# Altium schematic sheet decoder.SchDoc
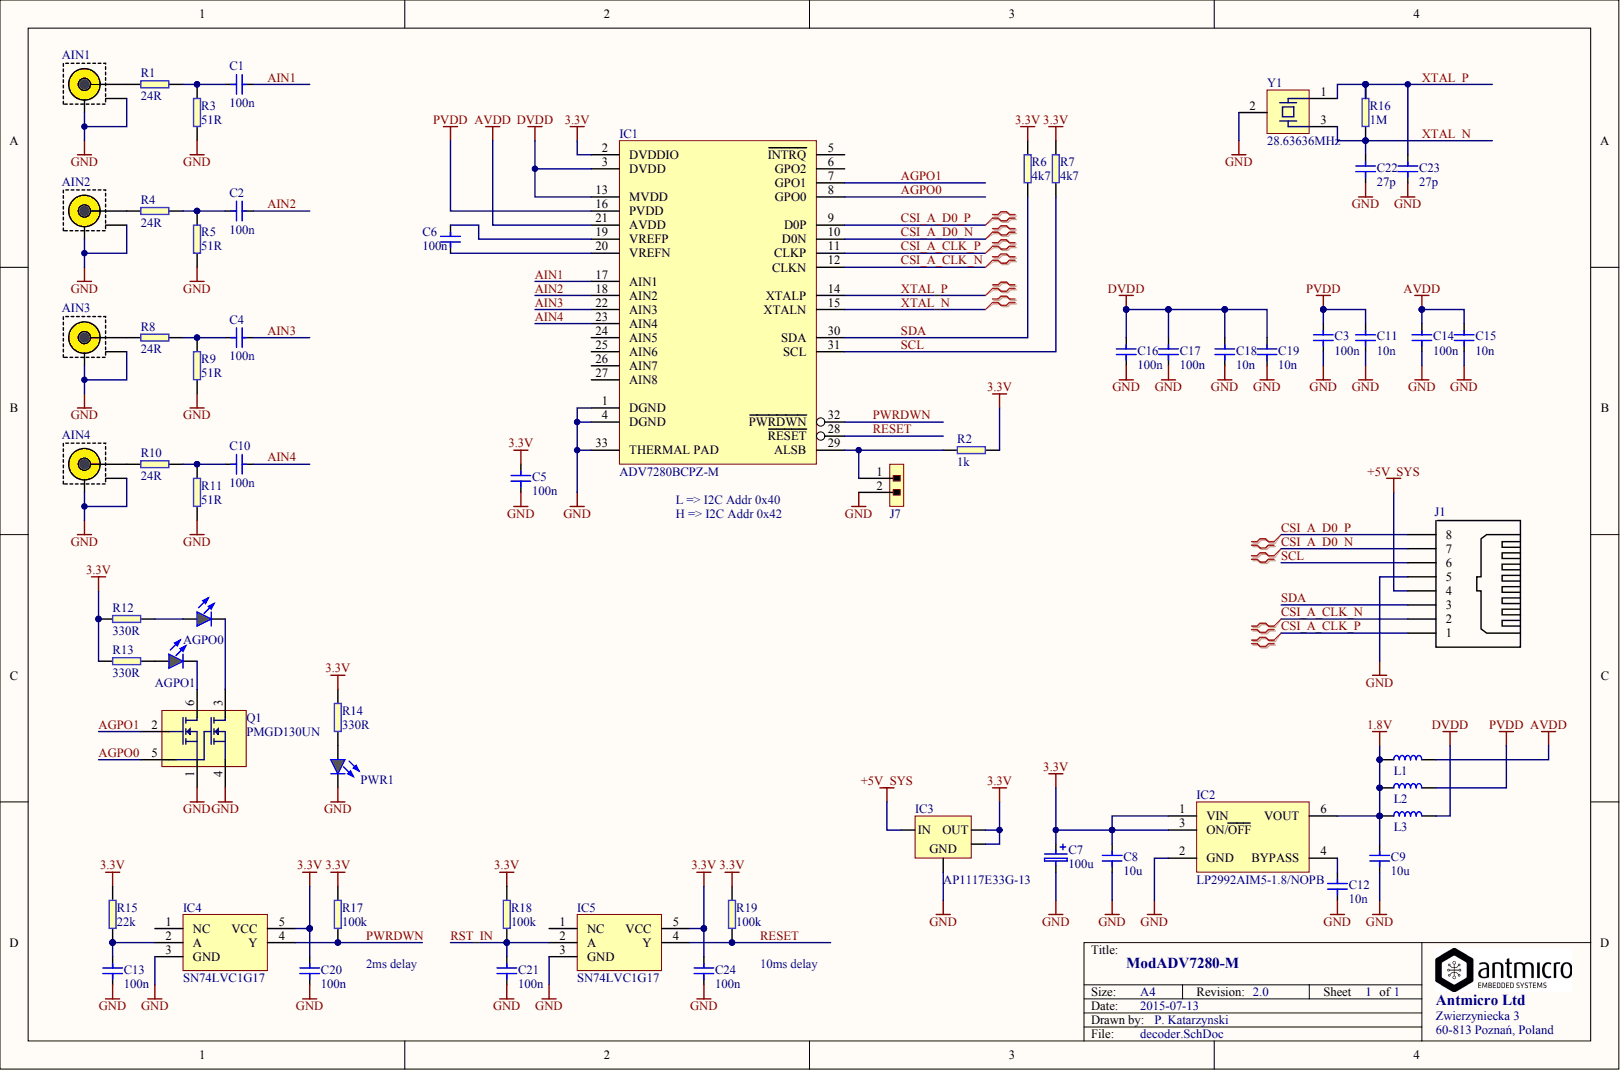
[diagram p0: decoder.SchDoc]

## Parts
AGPO0  *  [LED0603]  (value not drawn)
AGPO1  *  [LED0603]  (value not drawn)
AIN1  (no value)
AIN2  (no value)
AIN3  (no value)
AIN4  (no value)
C1  100n
C2  100n
C3  100n
C4  100n
C5  100n
C6  100n
C7  value 100u
C8  10u
C9  10u
C10  100n
C11  10n
C12  10n
C13  100n
C14  100n
C15  10n
C16  100n
C17  100n
C18  10n
C19  10n
C20  100n
C21  100n
C22  27p
C23  27p
C24  100n
IC1  ADV7280BCPZ-M
IC2  LP2992AIM5-1.8/NOPB
IC3  value AP1117E33G-13  (hidden comment: *)
IC4  SN74LVC1G17
IC5  SN74LVC1G17
J1  (no value)
J7  *  [HEADER_1X2_male 2.54mm]  (value not drawn)
L1  *  [0805]  (value not drawn)
L2  *  [0805]  (value not drawn)
L3  *  [0805]  (value not drawn)
PWR1  *  [LED0603]  (value not drawn)
Q1  PMGD130UN
R1  24R
R2  1k
R3  51R
R4  24R
R5  51R
R6  4k7
R7  4k7
R8  24R
R9  51R
R10  24R
R11  51R
R12  330R
R13  330R
R14  330R
R15  22k
R16  1M
R17  100k
R18  100k
R19  100k
Y1  28.63636MHz  [TXC_7B]

## Nets
1.8V: C9.2 IC2.6(VOUT) L1.1 L2.1 L3.1
3.3V: C5.2 C7.1 C8.2 C20.2 C24.2 IC1.2(DVDDIO) IC2.1(VIN) IC2.3(ON/OFF) IC3.2(OUT) IC3.4(GND) IC4.5(VCC) IC5.5(VCC) R2.1 R6.2 R7.2 R12.2 R13.2 R14.2 R15.2 R17.2 R18.2 R19.2
+5V_SYS: IC3.3(IN) J1.4
AVDD: C14.2 C15.2 IC1.21(AVDD) L1.2
DVDD: C16.2 C17.2 C18.2 C19.2 IC1.3(DVDD) IC1.13(MVDD) L3.2
GND: AIN1.GND AIN1.SHIELD AIN2.GND AIN2.SHIELD AIN3.GND AIN3.SHIELD AIN4.GND AIN4.SHIELD C3.1 C5.1 C7.2 C8.1 C9.1 C11.1 C12.1 C13.1 C14.1 C15.1 C16.1 C17.1 C18.1 C19.1 C20.1 C21.1 C22.1 C23.1 C24.1 IC1.1(DGND) IC1.4(DGND) IC1.33(THERMAL_PAD) IC2.2(GND) IC3.1 IC4.3(GND) IC5.3(GND) J1.5 J7.2 PWR1.K Q1.1 Q1.4 R3.1 R5.1 R9.1 R11.1 Y1.2
PVDD: C3.2 C11.2 IC1.16(PVDD) L2.2
AGPO0: IC1.8(GPO0) Q1.5
AGPO1: IC1.7(GPO1) Q1.2
AIN1: C1.2 IC1.17(AIN1)
AIN2: C2.2 IC1.18(AIN2)
AIN3: C4.2 IC1.22(AIN3)
AIN4: C10.2 IC1.23(AIN4)
CSI_A_CLK_N: IC1.12(CLKN) J1.2
CSI_A_CLK_P: IC1.11(CLKP) J1.1
CSI_A_D0_N: IC1.10(D0N) J1.7
CSI_A_D0_P: IC1.9(D0P) J1.8
PWRDWN: IC1.32(PWRDWN) IC4.4(Y) R17.1
RESET: IC1.28(RESET) IC5.4(Y) R19.1
RST_IN: C21.2 IC5.2(A) R18.1
SCL: IC1.31(SCL) J1.6 R7.1
SDA: IC1.30(SDA) J1.3 R6.1
XTAL_N: C22.2 IC1.15(XTALN) R16.1 Y1.3
XTAL_P: C23.2 IC1.14(XTALP) R16.2 Y1.1
NetAGPO0_A: AGPO0.A R12.1
NetAGPO0_K: AGPO0.K Q1.3
NetAGPO1_A: AGPO1.A R13.1
NetAGPO1_K: AGPO1.K Q1.6
NetAIN1_SIGNAL: AIN1.SIGNAL R1.1
NetAIN2_SIGNAL: AIN2.SIGNAL R4.1
NetAIN3_SIGNAL: AIN3.SIGNAL R8.1
NetAIN4_SIGNAL: AIN4.SIGNAL R10.1
NetC1_1: C1.1 R1.2 R3.2
NetC2_1: C2.1 R4.2 R5.2
NetC4_1: C4.1 R8.2 R9.2
NetC6_1: C6.1 IC1.20(VREFN)
NetC6_2: C6.2 IC1.19(VREFP)
NetC10_1: C10.1 R10.2 R11.2
NetC12_2: C12.2 IC2.4(BYPASS)
NetC13_2: C13.2 IC4.2(A) R15.1
NetIC1_29: IC1.29(ALSB) J7.1 R2.2
NetPWR1_A: PWR1.A R14.1

Not connected: IC1 5(INTRQ) 6(GPO2) 24(AIN5) 25(AIN6) 26(AIN7) 27(AIN8); IC4 1(NC); IC5 1(NC)

## Library data of the parts
AGPO0 AGPO1 PWR1 = LED: Part Number: LED_G; Value: KPT-1608SGC; Component Kind: Standard; Component Type: Standard; Pin Count: 2; Supplier 1: Farnell; Supplier Part Number 1: 2099223
AIN1-AIN4 = (no symbol name): Part Number: CC-134B; Supplier Part Number 1: CC-134B/GOLD; Supplier 1: TME
C1-C6 C10 C13 C14 C16 C17 C20 C21 C24 = (no symbol name): Part Number: C_100n_0603; Tolerance: 5%; Value: 100n; Component type: standard; Pin count: 2; Supplier 1: Farnell; Supplier Part Number 1: 2332586
C7 = (no symbol name): Part Number: C_100u_KEMET_A; Component type: standard; Pin count: 2; Supplier 1: Farnell; Supplier Part Number 1: 1961933
C8 C9 = (no symbol name): Part Number: C_10u_0603; Value: 10u; Component type: standard; Pin count: 2; Supplier 1: Farnell; Supplier Part Number 1: 1759393
C11 C12 C15 C18 C19 = (no symbol name): Part Number: C_10n_0603; Value: 10n; Component type: standard; Pin count: 2; Supplier 1: Farnell; Supplier Part Number 1: 1833876
C22 C23 = (no symbol name): Part Number: C_27p_0603; Value: 27p; Component type: standard; Pin count: 2; Supplier 1: Farnell; Supplier Part Number 1: 1758870
J1 = (no symbol name): Part Number: RJ45 AMP-1-406541; Supplier Part Number 1: 1162481; Supplier 1: Farnell
J7 = HEADER_1X2_male: Part Number: HEADER_1X2_male; Component Kind: Standard; Type: Standard; Pin Count: 2
L1-L3 = FerriteBead: Part Number: 742792022; Current: 2A; Resistance: 0.05R; Tolerance: 25%; Component Kind: Standard; Component Type: Standard; Pin Count: 2; Supplier 1: Farnell; Supplier Part Number 1: 1635733
R1 R4 R8 R10 = (no symbol name): Part Number: R_24R_0603; Value: 24R; Component type: standard; Pin count: 2; Supplier 1: Farnell; Supplier Part Number 1: 1627705RL
R2 = (no symbol name): Part Number: R_1k_0603; Tolerance: 5%; Value: 1k; Component type: standard; Pin count: 2; Supplier 1: Farnell; Supplier Part Number 1: 2333561
R3 R5 R9 R11 = (no symbol name): Part Number: R_51R_0805; Tolerance: 1%; Value: 51R; Component type: standard; Pin count: 2; Supplier 1: Farnell; Supplier Part Number 1: 1865239
R6 R7 = (no symbol name): Part Number: R_4k7_0603; Value: 4k7; Component type: standard; Pin count: 2; Supplier 1: Farnell; Supplier Part Number 1: 2008340
R12-R14 = (no symbol name): Part Number: R_330R_0603; Tolerance: 5%; Value: 330R; Component type: standard; Pin count: 2; Supplier 1: Farnell; Supplier Part Number 1: 2008334
R15 = (no symbol name): Part Number: R_22k_0603; Value: 22k; Component type: standard; Pin count: 2; Supplier 1: Farnell; Supplier Part Number 1: 2008363
R16 = (no symbol name): Part Number: R_1M_0603; Value: 1M; Component type: standard; Pin count: 2; Supplier 1: Farnell; Supplier Part Number 1: 2008367
R17-R19 = (no symbol name): Part Number: R_100k_0603; Tolerance: 5%; Value: 100k; Component type: standard; Pin count: 2; Supplier 1: Farnell; Supplier Part Number 1: 2008366
Y1 = TXC_7B: Part Number: 7B-28.63636MAAJ-T; Component Kind: Standard; Component Type: Standard; Pin Count: 4; Value: 28.63636MHz; Supplier 1: Farnell; Supplier Part Number 1: 1842003RL
IC1-IC5 Q1 = (no symbol name)
  IC1: Part Number: ADV7280BCPZ-M; Value: ADV7280BCPZ-M; Supplier 1: Mouser; Supplier Part Number 1: ADV7280BCPZ-M
  IC2: Part Number: LP2992AIM5-1.8; Supplier 1: Farnell; Supplier Part Number 1: 2436470; Value: LP2992AIM5-1.8/NOPB
  IC3: Part Number: AP1117E33G-13; Supplier 1: Farnell; Supplier Part Number 1: 1825291
  IC4 IC5: Part Number: SN74LVC1G17; Value: SN74LVC1G17; Supplier 1: Farnell; Supplier Part Number 1: 1236333; Supplier 2: Mouser; Supplier Part Number 2: 595-74LVC1G17MDCKREP
  Q1: Part Number: PMGD130UN; Value: PMGD130UN; Supplier 1: Farnell; Supplier Part Number 1: 2311192
